# Altium schematic sheet Sheet1.SchDoc(Sheet1)
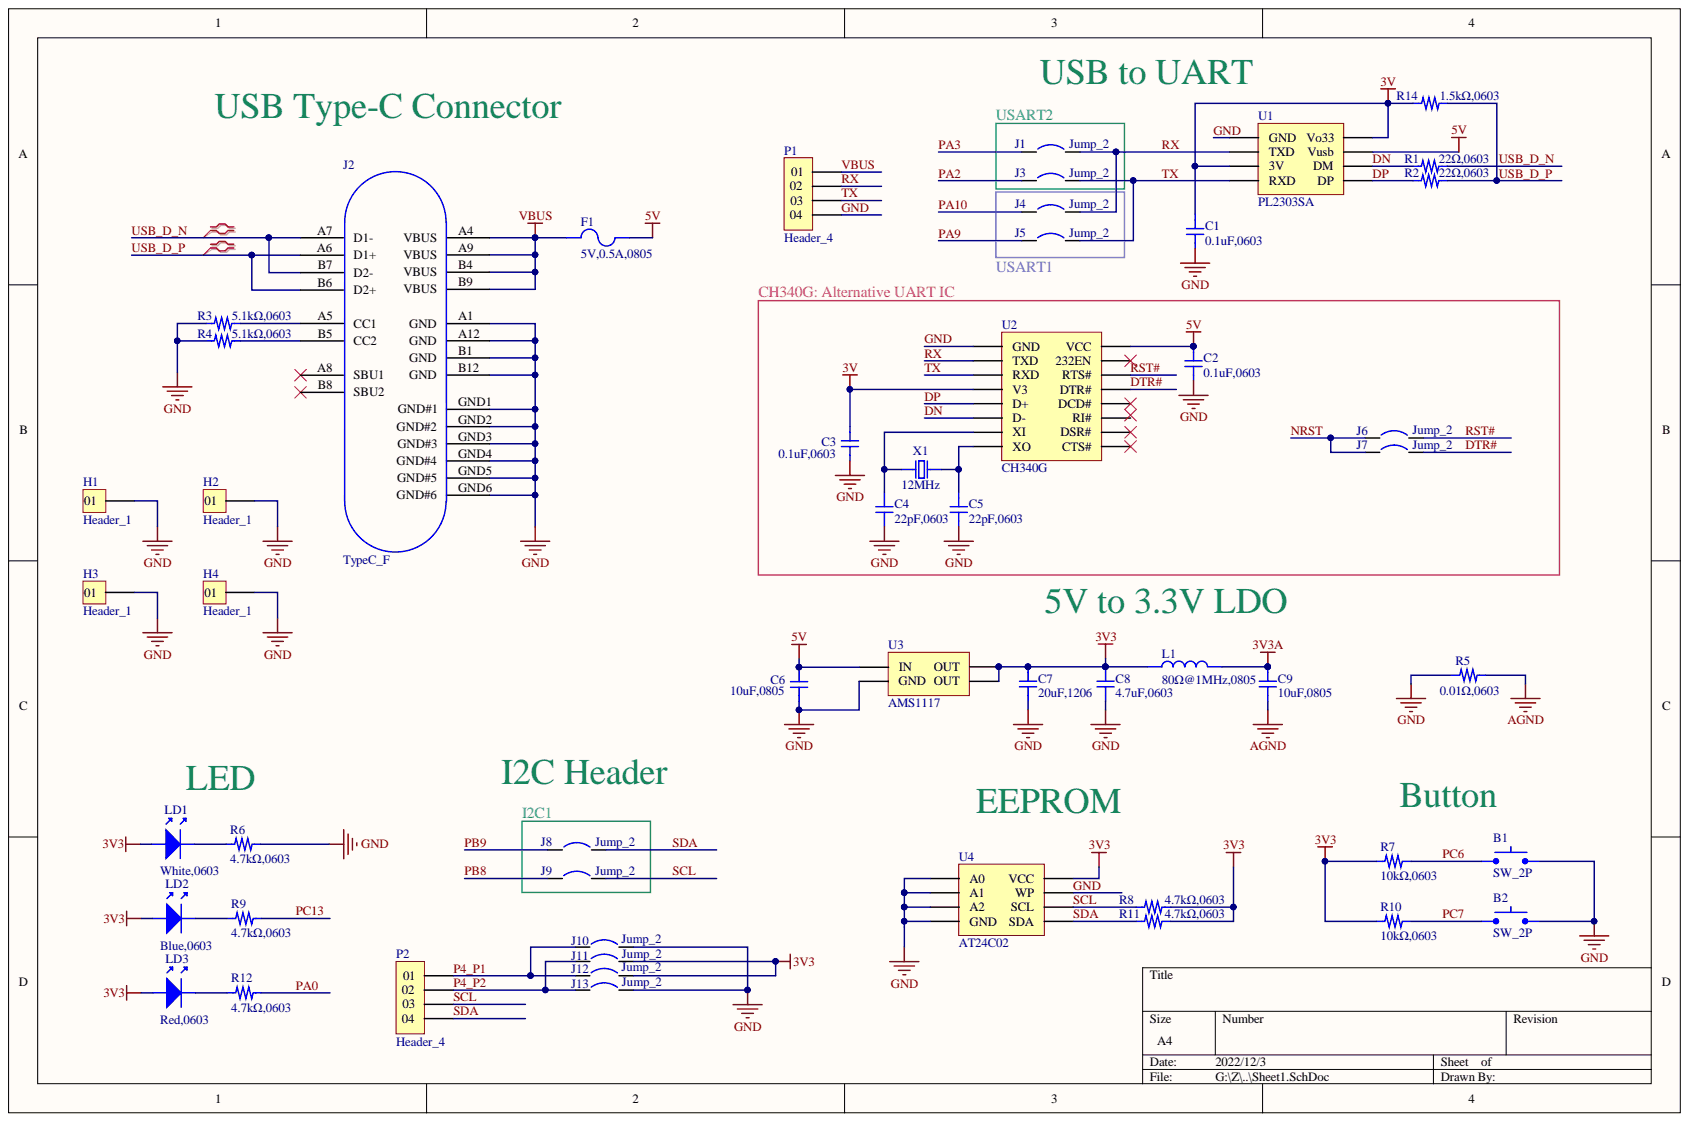
[diagram p0: Sheet1.SchDoc]

## Parts
B1  SW_2P  [SW_2P_3]
B2  SW_2P  [SW_2P_3]
C1  0.1uF,0603  [C0603]
C2  0.1uF,0603  [C0603]
C3  0.1uF,0603  [C0603]
C4  22pF,0603  [C0603]
C5  22pF,0603  [C0603]
C6  10uF,0805  [C0805]
C7  20uF,1206  [C1206]
C8  4.7uF,0603  [C0603]
C9  10uF,0805  [C0805]
F1  5V,0.5A,0805  [F0805]
H1  Header_1  [Header_1_GND]
H2  Header_1  [Header_1_GND]
H3  Header_1  [Header_1_GND]
H4  Header_1  [Header_1_GND]
J1  Jump_2  [Jp_small]
J2  TypeC_F  [Type-C_16P_F_0.5_BOTH]
J3  Jump_2  [Jp_small]
J4  Jump_2  [Jp_small]
J5  Jump_2  [Jp_small]
J6  Jump_2  [Jp_small]
J7  Jump_2  [Jp_small]
J8  Jump_2  [Jp_small]
J9  Jump_2  [Jp_small]
J10  Jump_2  [Jp_small]
J11  Jump_2  [Jp_small]
J12  Jump_2  [Jp_small]
J13  Jump_2  [Jp_small]
L1  80Ω@1MHz,0805  [L0805]
LD1  White,0603  [LED0603]
LD2  Blue,0603  [LED0603]
LD3  Red,0603  [LED0603]
P1  Header_4  [Header_4]
P2  Header_4  [Header_4]
R1  22Ω,0603  [R0603]
R2  22Ω,0603  [R0603]
R3  5.1kΩ,0603  [R0603]
R4  5.1kΩ,0603  [R0603]
R5  0.01Ω,0603  [R0603]
R6  4.7kΩ,0603  [R0603]
R7  10kΩ,0603  [R0603]
R8  4.7kΩ,0603  [R0603]
R9  4.7kΩ,0603  [R0603]
R10  10kΩ,0603  [R0603]
R11  4.7kΩ,0603  [R0603]
R12  4.7kΩ,0603  [R0603]
R14  1.5kΩ,0603  [R0603]
U1  PL2303SA  [SOP8]
U2  CH340G  [SOP16]
U3  AMS1117  [SOT-223]
U4  AT24C02  [SOP8]
X1  12MHz  [XTAL_SMD_3225]

## Nets
3V: C1.02 C3.02 R14.02 U1.03(3V) U1.08(Vo33) U2.04(V3)
3V3: C7.02 C8.02 J11.02 J12.02 L1.01 LD1.01 LD2.01 LD3.01 R7.01 R8.02 R10.01 R11.02 U3.02(OUT) U3.04(OUT) U4.08(VCC)
3V3A: C9.02 L1.02
5V: C2.01 C6.02 F1.02 U1.07(Vusb) U2.16(VCC) U3.03(IN)
AGND: C9.01 R5.01
DN: R1.01 U1.06(DM) U2.06(D-)
DP: R2.01 U1.05(DP) U2.05(D+)
DTR#: J7.02 U2.13(DTR#)
GND: B1.02 B2.02 C1.01 C2.02 C3.01 C4.01 C5.01 C6.01 C7.01 C8.01 H1.01 H2.01 H3.01 H4.01 J2.A1(GND) J2.A12(GND) J2.B1(GND) J2.B12(GND) J2.GND1(GND#1) J2.GND2(GND#2) J2.GND3(GND#3) J2.GND4(GND#4) J2.GND5(GND#5) J2.GND6(GND#6) J10.02 J13.02 P1.04 R3.01 R4.01 R5.02 R6.01 U1.01(GND) U2.01(GND) U3.01(GND) U4.01(A0) U4.02(A1) U4.03(A2) U4.04(GND) U4.07(WP)
NRST: J6.01 J7.01
P4_P1: J10.01 J12.01 P2.01
P4_P2: J11.01 J13.01 P2.02
PA0: R12.01
PA2: J3.01
PA3: J1.01
PA9: J5.01
PA10: J4.01
PB8: J9.01
PB9: J8.01
PC6: B1.01 R7.02
PC7: B2.01 R10.02
PC13: R9.01
RST#: J6.02 U2.14(RTS#)
RX: J1.02 J4.02 P1.02 U1.02(TXD) U2.02(TXD)
SCL: J9.02 P2.03 R8.01 U4.06(SCL)
SDA: J8.02 P2.04 R11.01 U4.05(SDA)
TX: J3.02 J5.02 P1.03 U1.04(RXD) U2.03(RXD)
USB_D_N: J2.A7(D1-) J2.B7(D2-) R1.02
USB_D_P: J2.A6(D1+) J2.B6(D2+) R2.02 R14.01
VBUS: F1.01 J2.A4(VBUS) J2.A9(VBUS) J2.B4(VBUS) J2.B9(VBUS) P1.01
NetC4_02: C4.02 U2.07(XI) X1.01
NetC5_02: C5.02 U2.08(XO) X1.02
NetJ2_A5: J2.A5(CC1) R3.02
NetJ2_B5: J2.B5(CC2) R4.02
NetLD1_02: LD1.02 R6.02
NetLD2_02: LD2.02 R9.02
NetLD3_02: LD3.02 R12.02

Not connected: J2 A8(SBU1) B8(SBU2); U2 09(CTS#) 10(DSR#) 11(RI#) 12(DCD#) 15(232EN)

## Library data of the parts
C1-C9 = Cap: Component Kind: Standard; Library Name: myLib1.IntLib
F1 = Fuse: Component Kind: Standard; Library Name: myLib1.IntLib
J2 = Type-C_16P_F_0.5: Female SMD Connector; Component Kind: Standard; Library Name: myLib1.IntLib
L1 = Ind: Component Kind: Standard; Library Name: myLib1.IntLib
LD1-LD3 = Diode - LED: Component Kind: Standard; Library Name: myLib1.IntLib
R1-R12 R14 = Res: Component Kind: Standard; Library Name: myLib1.IntLib
X1 = XTAL: Component Kind: Standard; Library Name: myLib1.IntLib
B1 B2 = SW_2P: Component Kind: Standard; Library Name: myLib1.IntLib
H1-H4 = Header_1: Component Kind: Standard; Library Name: myLib1.IntLib
J1 J3-J13 = Jump_2: Component Kind: Standard; Library Name: myLib1.IntLib
P1 P2 = Header_4: Component Kind: Standard; Library Name: myLib1.IntLib
U1 = PL2303SA: Component Kind: Standard; Library Name: myLib1.IntLib
U2 = CH340G: Component Kind: Standard; Library Name: myLib1.IntLib
U3 = AMS1117: Component Kind: Standard; Library Name: myLib1.IntLib
U4 = AT24C02: Component Kind: Standard; Library Name: myLib1.IntLib
